# Altium schematic sheet P770010E05-Xx-SH-2_AIS.SchDoc(P770010E05-Xx-SH-2_AIS)
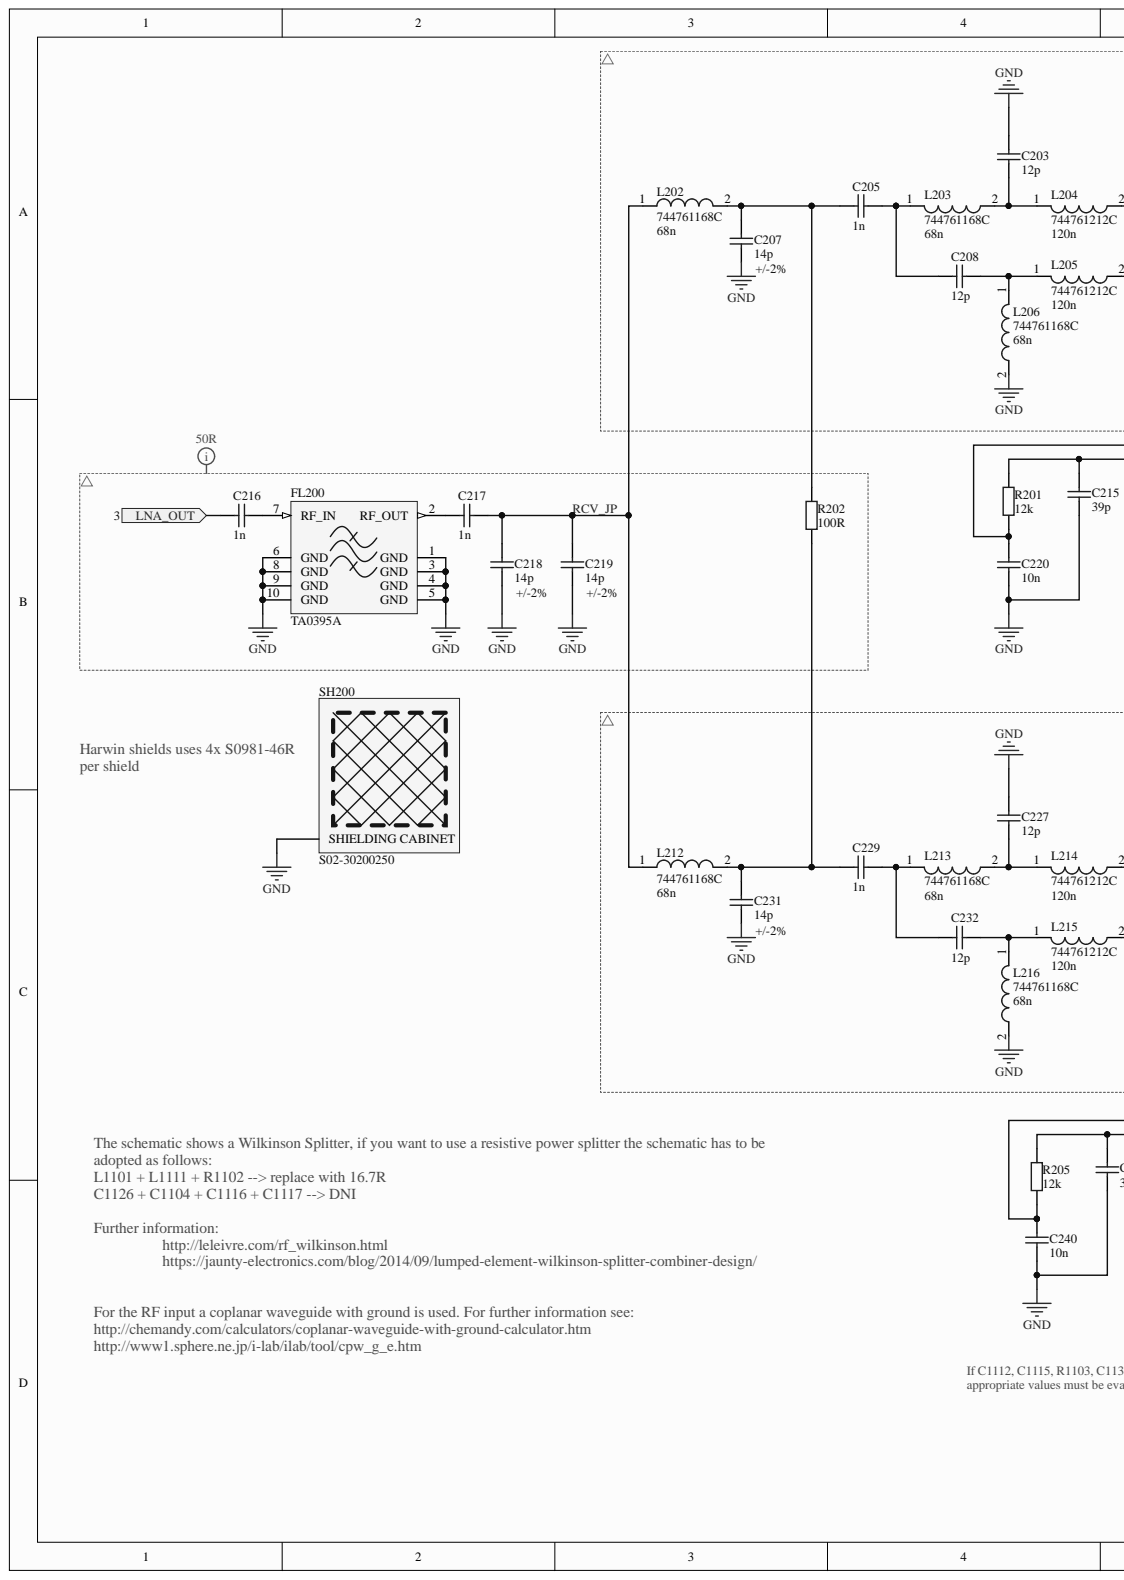
[diagram p0: P770010E05-Xx-SH-2_AIS.SchDoc, left]
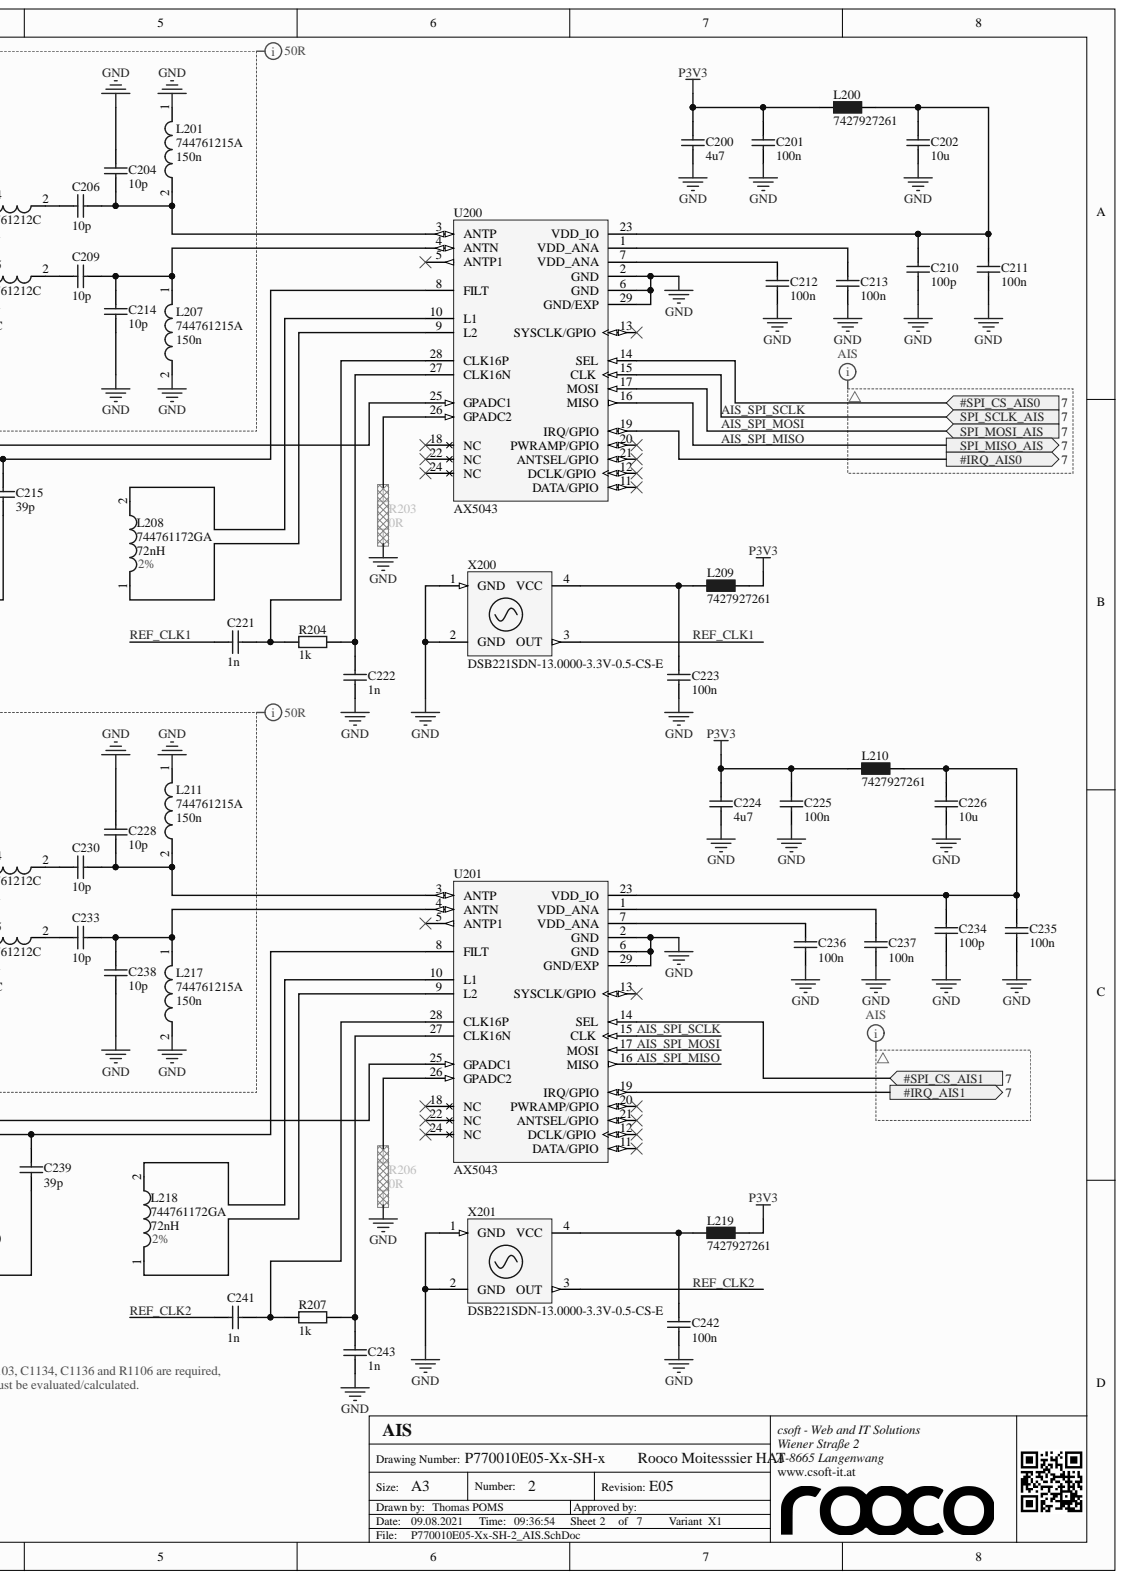
[diagram p1: P770010E05-Xx-SH-2_AIS.SchDoc, right]

## Parts
C200  4u7  [C0402]
C201  100n  [C0402]
C202  10u  [C0603]
C203  12p  [C0402]
C204  10p  [C0402]
C205  1n  [C0402]
C206  10p  [C0402]
C207  14p  ToleranceValue: +/-2%  [C0402]
C208  12p  [C0402]
C209  10p  [C0402]
C210  100p  [C0402]
C211  100n  [C0402]
C212  100n  [C0402]
C213  100n  [C0402]
C214  10p  [C0402]
C215  39p  [C0402]
C216  1n  [C0402]
C217  1n  [C0402]
C218  14p  ToleranceValue: +/-2%  [C0402]
C219  14p  ToleranceValue: +/-2%  [C0402]
C220  10n  [C0402]
C221  1n  [C0402]
C222  1n  [C0402]
C223  100n  [C0402]
C224  4u7  [C0402]
C225  100n  [C0402]
C226  10u  [C0603]
C227  12p  [C0402]
C228  10p  [C0402]
C229  1n  [C0402]
C230  10p  [C0402]
C231  14p  ToleranceValue: +/-2%  [C0402]
C232  12p  [C0402]
C233  10p  [C0402]
C234  100p  [C0402]
C235  100n  [C0402]
C236  100n  [C0402]
C237  100n  [C0402]
C238  10p  [C0402]
C239  39p  [C0402]
C240  10n  [C0402]
C241  1n  [C0402]
C242  100n  [C0402]
C243  1n  [C0402]
FL200  TA0395A  [TA0395A_with_bypass_but_no_resistor]
L200  7427927261  [7427927261]
L201  744761215A  value 150n  [744761215A_0603]
L202  744761168C  value 68n  [744761168C_0603]
L203  744761168C  value 68n  [744761168C_0603]
L204  744761212C  value 120n  [744761212C_0603]
L205  744761212C  value 120n  [744761212C_0603]
L206  744761168C  value 68n  [744761168C_0603]
L207  744761215A  value 150n  [744761215A_0603]
L208  744761172GA  value 72nH  [744761172A_0603]
L209  7427927261  [7427927261]
L210  7427927261  [7427927261]
L211  744761215A  value 150n  [744761215A_0603]
L212  744761168C  value 68n  [744761168C_0603]
L213  744761168C  value 68n  [744761168C_0603]
L214  744761212C  value 120n  [744761212C_0603]
L215  744761212C  value 120n  [744761212C_0603]
L216  744761168C  value 68n  [744761168C_0603]
L217  744761215A  value 150n  [744761215A_0603]
L218  744761172GA  value 72nH  [744761172A_0603]
L219  7427927261  [7427927261]
R201  12k  [R0402]
R202  100R  [R0402]
R203  0R  [R0402]
R204  1k  [R0402]
R205  12k  [R0402]
R206  0R  [R0402]
R207  1k  [R0402]
SH200  S02-30200250  [S02-30200250_Wuerth_36103305_fewer_pads_V2]
U200  AX5043  [AX5043_QFN-28]
U201  AX5043  [AX5043_QFN-28]
X200  DSB221SDN-13.0000-3.3V-0.5-CS-E  [DSB221SDN-x]
X201  DSB221SDN-13.0000-3.3V-0.5-CS-E  [DSB221SDN-x]

## Ports
#IRQ_AIS0, #IRQ_AIS1, #SPI_CS_AIS0, #SPI_CS_AIS1, LNA_OUT, SPI_MISO_AIS, SPI_MOSI_AIS, SPI_SCLK_AIS

## Nets
GND: C200.1 C201.1 C202.1 C203.1 C204.1 C207.1 C210.1 C211.1 C212.1 C213.1 C214.2 C215.1 C218.1 C219.1 C220.1 C222.1 C223.1 C224.1 C225.1 C226.1 C227.1 C228.1 C231.1 C234.1 C235.1 C236.1 C237.1 C238.2 C239.1 C240.1 C242.1 C243.1 FL200.1(GND) FL200.3(GND) FL200.4(GND) FL200.5(GND) FL200.6(GND) FL200.8(GND) FL200.9(GND) FL200.10(GND) L201.1 L206.2 L207.2 L211.1 L216.2 L217.2 R203.1 R206.1 SH200.1 U200.2(GND) U200.6(GND) U200.29(GND/EXP) U201.2(GND) U201.6(GND) U201.29(GND/EXP) X200.1(GND) X200.2(GND) X201.1(GND) X201.2(GND)
P3V3: C200.2 C201.2 C224.2 C225.2 L200.1 L209.2 L210.1 L219.2
#IRQ_AIS0: U200.19(IRQ/GPIO) ; port #IRQ_AIS0
#IRQ_AIS1: U201.19(IRQ/GPIO) ; port #IRQ_AIS1
#SPI_CS_AIS0: U200.14(SEL) ; port #SPI_CS_AIS0
#SPI_CS_AIS1: U201.14(SEL) ; port #SPI_CS_AIS1
AIS_SPI_MISO: U200.16(MISO) U201.16(MISO) ; port SPI_MISO_AIS
AIS_SPI_MOSI: U200.17(MOSI) U201.17(MOSI) ; port SPI_MOSI_AIS
AIS_SPI_SCLK: U200.15(CLK) U201.15(CLK) ; port SPI_SCLK_AIS
LNA_OUT: C216.1 ; port LNA_OUT
RCV_JP: C217.2 C218.2 C219.2 L202.1 L212.1
REF_CLK1: C221.1 X200.3(OUT)
REF_CLK2: C241.1 X201.3(OUT)
NetC202_2: C202.2 C210.2 C211.2 L200.2 U200.23(VDD_IO)
NetC203_2: C203.2 L203.2 L204.1
NetC204_2: C204.2 C206.2 L201.2 U200.3(ANTP)
NetC205_1: C205.1 C207.2 L202.2 R202.2
NetC205_2: C205.2 C208.1 L203.1
NetC206_1: C206.1 L204.2
NetC208_2: C208.2 L205.1 L206.1
NetC209_1: C209.1 L205.2
NetC209_2: C209.2 C214.1 L207.1 U200.4(ANTN)
NetC212_2: C212.2 U200.7(VDD_ANA)
NetC213_2: C213.2 U200.1(VDD_ANA)
NetC215_2: C215.2 R201.2 U200.8(FILT)
NetC216_2: C216.2 FL200.7(RF_IN)
NetC217_1: C217.1 FL200.2(RF_OUT)
NetC220_2: C220.2 R201.1 U200.25(GPADC1)
NetC221_2: C221.2 R204.2 U200.28(CLK16P)
NetC222_2: C222.2 R204.1 U200.27(CLK16N)
NetC223_2: C223.2 L209.1 X200.4(VCC)
NetC226_2: C226.2 C234.2 C235.2 L210.2 U201.23(VDD_IO)
NetC227_2: C227.2 L213.2 L214.1
NetC228_2: C228.2 C230.2 L211.2 U201.3(ANTP)
NetC229_1: C229.1 C231.2 L212.2 R202.1
NetC229_2: C229.2 C232.1 L213.1
NetC230_1: C230.1 L214.2
NetC232_2: C232.2 L215.1 L216.1
NetC233_1: C233.1 L215.2
NetC233_2: C233.2 C238.1 L217.1 U201.4(ANTN)
NetC236_2: C236.2 U201.7(VDD_ANA)
NetC237_2: C237.2 U201.1(VDD_ANA)
NetC239_2: C239.2 R205.2 U201.8(FILT)
NetC240_2: C240.2 R205.1 U201.25(GPADC1)
NetC241_2: C241.2 R207.2 U201.28(CLK16P)
NetC242_2: C242.2 L219.1 X201.4(VCC)
NetC243_2: C243.2 R207.1 U201.27(CLK16N)
NetL208_1: L208.1 U200.9(L2)
NetL208_2: L208.2 U200.10(L1)
NetL218_1: L218.1 U201.9(L2)
NetL218_2: L218.2 U201.10(L1)
NetR203_2: R203.2 U200.26(GPADC2)
NetR206_2: R206.2 U201.26(GPADC2)
N00068:
N00069:
N00070:
N00071:
N00072:

Not connected: U200 5(ANTP1) 11(DATA/GPIO) 12(DCLK/GPIO) 13(SYSCLK/GPIO) 18(NC) 20(PWRAMP/GPIO) 21(ANTSEL/GPIO) 22(NC) 24(NC); U201 5(ANTP1) 11(DATA/GPIO) 12(DCLK/GPIO) 13(SYSCLK/GPIO) 18(NC) 20(PWRAMP/GPIO) 21(ANTSEL/GPIO) 22(NC) 24(NC)

## Library data of the parts
C200 C224 = C1005X5R0J475K: ceramic chip capacitor, 4.7uF, 6.3V, X5R, 0402; Manufacturer: TDK; ID: 10; Obsolete: False; Published by: FT-TEC; RevisionHistory: [1.0]; ToleranceValue: +/-15%; Component Kind: Standard; ComponentLink1Description: Datasheet; ComponentLink1URL: http://www.tdk.com/pdf/TDKMLCCCapRange.pdf; ComponentType: Standard; Database Table Name: Capacitor; Dielectric: X5R; Library Name: CompanyDatabase.DbLib; Package: 0402; Part Number: C1005X5R0J475K; Pin Count: 2; Published: 24.02.2012; Revision: 1.0; TemperatureMax: 85°C; TemperatureMin: -55°C; Value: 4u7; VoltageTypical: 6.3V; Checked by: Thomas POMS; Drawn by: Thomas POMS
C201 C211-C213 C223 C225 C235-C237 C242 = C1005X7R1H104K050BB: ceramic chip capacitor, 100n, 50V, X7R, 0402; Manufacturer: TDK; ID: 1; Obsolete: False; Published by: FT-TEC; RevisionHistory: [1.0]; ToleranceValue: +/-10%; Component Kind: Standard; ComponentLink1Description: Datasheet; ComponentLink1URL: http://www.tdk.com/pdf/TDKMLCCCapRange.pdf; ComponentType: Standard; Database Table Name: Capacitor; Dielectric: X7R; Library Name: CompanyDatabase.DbLib; Package: 0402; Part Number: C1005X7R1H104K050BB; Pin Count: 2; Published: 16.02.2012; Revision: 1.0; TemperatureMax: 125°C; TemperatureMin: -55°C; Value: 100n; VoltageTypical: 50V; Checked by: Thomas POMS; Drawn by: Thomas POMS
C202 C226 = GRM188R61C106KAALJ: ceramic chip capacitor, 10uF, 16V, X5R, 0603; Manufacturer: Murata; ID: 68; Obsolete: False; Published by: FT-TEC; RevisionHistory: [1.0] Creation; ToleranceValue: +/-10%; Component Kind: Standard; ComponentLink1Description: Datasheet; ComponentLink1URL: http://search.murata.co.jp/Ceramy/image/img/A01X/partnumbering_e_01.pdf; ComponentType: Standard; Database Table Name: Capacitor; Dielectric: X5R; Library Name: CompanyDatabase.DbLib; Package: 0603; Part Number: GRM188R61C106KAALJ; Pin Count: 2; Published: 03.09.2014; Revision: 1.0; TemperatureMax: 85°C; TemperatureMin: -55°C; Value: 10u; VoltageTypical: 16V; Checked by: Thomas POMS; Drawn by: Thomas POMS
C203 C208 C227 C232 = CC0402JRNPO9BN120: ceramic chip capacitor, 12p, 50V, C0G, 0402ceramic chip c; Manufacturer: Yageo; ID: 4; Obsolete: False; Published by: FT-TEC; RevisionHistory: [1.0]; ToleranceValue: +/-5%; Component Kind: Standard; ComponentLink1Description: Datasheet; ComponentLink1URL: http://www.yageo.com/documents/recent/UPY-GP_NP0_16V-to-50V_8.pdf; ComponentType: Standard; Database Table Name: Capacitor; Dielectric: C0G; Library Name: CompanyDatabase.DbLib; Package: 0402; Part Number: CC0402JRNPO9BN120; Pin Count: 2; Published: 16.02.2012; Revision: 1.0; TemperatureMax: 125°C; TemperatureMin: -55°C; Value: 12p; VoltageTypical: 50V; Checked by: Thomas POMS; Drawn by: Thomas POMS
C204 C206 C209 C214 C228 C230 C233 C238 = C0402C100C5GACTU: ceramic chip capacitor, 10pF, 50V, NP0, 0402; Manufacturer: Kemet; ID: 55; Obsolete: False; Published by: FT-TEC; RevisionHistory: [1.0] Creation; ToleranceValue: +/-0.25%; Component Kind: Standard; ComponentLink1Description: Datasheet; ComponentLink1URL: http://www.kemet.com/kemet/web/homepage/kechome.nsf/vapubfiles/KEM_C1003_C0G_SMD.pdf/$file/KEM_C1003_C0G_SMD.pdf; ComponentType: Standard; Database Table Name: Capacitor; Dielectric: NP0; Library Name: CompanyDatabase.DbLib; Package: 0402; Part Number: C0402C100C5GACTU; Pin Count: 2; Published: 17.03.2014; Revision: 1.0; TemperatureMax: 125°C; TemperatureMin: -55°C; Value: 10p; VoltageTypical: 50V; Checked by: Thomas POMS; Drawn by: Thomas POMS
C205 C216 C217 C221 C222 C229 C241 C243 = C1005C0G1H102G050BA: ceramic chip capacitor, 1nF, 50V, NP0, 0402; Manufacturer: TDK; ID: 25; Obsolete: False; Published by: FT-TEC; RevisionHistory: [1.0]; ToleranceValue: +/-2%; Component Kind: Standard; ComponentLink1Description: Datasheet; ComponentLink1URL: http://www.tdk.com/pdf/tight_tol_B11.pdf; ComponentType: Standard; Database Table Name: Capacitor; Dielectric: NP0; Library Name: CompanyDatabase.DbLib; Package: 0402; Part Number: C1005C0G1H102G050BA; Pin Count: 2; Published: 26.02.2013; Revision: 1.0; TemperatureMax: 125°C; TemperatureMin: -55°C; Value: 1n; VoltageTypical: 50V; Checked by: Thomas POMS; Drawn by: Thomas POMS
C207 C218 C219 C231 = 04023U140GAT2A: ceramic chip capacitor, 14pF, 25V, NP0, 0402; Manufacturer: AVX; ID: 69; Obsolete: False; Published by: FT-TEC; RevisionHistory: [1.0] Creation; Component Kind: Standard; ComponentLink1Description: Datasheet; ComponentLink1URL: http://www.avx.com/docs/Catalogs/useries.pdf; ComponentType: Standard; Database Table Name: Capacitor; Dielectric: NP0; Library Name: CompanyDatabase.DbLib; Package: 0402; Part Number: 04023U140GAT2A; Pin Count: 2; Published: 28.10.2014; Revision: 1.0; TemperatureMax: 125°C; TemperatureMin: -55°C; Value: 14p; VoltageTypical: 25V; Checked by: Thomas POMS; Drawn by: Thomas POMS
C210 C234 = UMK105CG101JV: ceramic chip capacitor, 100pF, 50V, C0G, 0402; Manufacturer: Taiyo Yuden; ID: 17; Obsolete: False; Published by: FT-TEC; RevisionHistory: [1.0]; ToleranceValue: +/-5%; Component Kind: Standard; ComponentLink1Description: Datasheet; ComponentLink1URL: http://media.digikey.com/pdf/Data%20Sheets/Taiyo%20Yuden%20PDFs%20URL%20links/Standard%20Multilayer%20Ceramic%20Caps.pdf; ComponentType: Standard; Database Table Name: Capacitor; Dielectric: C0G; Library Name: CompanyDatabase.DbLib; Package: 0402; Part Number: UMK105CG101JV; Pin Count: 2; Published: 27.02.2012; Revision: 1.0; TemperatureMax: 125°C; TemperatureMin: -55°C; Value: 100p; VoltageTypical: 50V; Checked by: Thomas POMS; Drawn by: Thomas POMS
C215 C239 = C0402C390J5GACTU: ceramic chip capacitor, 39pF, 50V, NP0, 0402; Manufacturer: Kemet; ID: 72; Obsolete: False; Published by: FT-TEC; RevisionHistory: [1.0] Creation; ToleranceValue: +/-5%; Component Kind: Standard; ComponentLink1Description: Datasheet; ComponentLink1URL: http://www.kemet.com/Lists/ProductCatalog/Attachments/46/KEM_C1003_C0G_SMD.pdf; ComponentType: Standard; Database Table Name: Capacitor; Dielectric: NP0; Library Name: CompanyDatabase.DbLib; Package: 0402; Part Number: C0402C390J5GACTU; Pin Count: 2; Published: 14.02.2015; Revision: 1.0; TemperatureMax: 125°C; TemperatureMin: -55°C; Value: 39p; VoltageTypical: 50V; Checked by: Thomas POMS; Drawn by: Thomas POMS
C220 C240 = GCM155R71H103KA55D: ceramic chip capacitor, 10nF, 50V, X7R, 0402; Manufacturer: Murata; ID: 13; Obsolete: False; Published by: FT-TEC; RevisionHistory: [1.0]; ToleranceValue: +/-10%; Component Kind: Standard; ComponentLink1Description: Datasheet; ComponentLink1URL: http://search.murata.co.jp/Ceramy/image/img/PDF/ENG/GCM155R71H103KA55.pdf; ComponentType: Standard; Database Table Name: Capacitor; Dielectric: X7R; Library Name: CompanyDatabase.DbLib; Package: 0402; Part Number: GCM155R71H103KA55D; Pin Count: 2; Published: 26.02.2012; Revision: 1.0; TemperatureMax: 125°C; TemperatureMin: -55°C; Value: 10n; VoltageTypical: 50V; Checked by: Thomas POMS; Drawn by: Thomas POMS
FL200 = TA0395A: SAW filter 159.1625 MHz, max. 20 dBm continuous power input level; Manufacturer: Golledge; Database Table Name: RF_Filter; ID: 1; Obsolete: False; Published by: FT-TEC; RevisionHistory: [1.0] Creation; Component Kind: Standard; ComponentType: Standard; Library Name: CompanyDatabase.DbLib; Package: 7.0x5.0mm; Part Number: TA0395A; Pin Count: 10; Published: 08.07.2013; Revision: 1.0; TemperatureMax: 70°C; TemperatureMin: -30°C; Value: TA0395A; Checked by: Thomas POMS; Drawn by: Thomas POMS
L200 L209 L210 L219 = 7427927261: EMI suppression ferrite bead, 100mA, 0.6R, Z=600R@100MHz; Manufacturer: Würth; Database Table Name: Inductor; ID: 12; Obsolete: False; Published by: FT-TEC; RevisionHistory: [1.0] Creation; Component Kind: Standard; ComponentLink1Description: Datasheet; ComponentLink1URL: http://katalog.we-online.de/kataloge/eisos/media/pdf/7427927261.pdf; ComponentType: Standard; CurrentRating: 100mA; Impedance: 600R@100MHz; Library Name: CompanyDatabase.DbLib; Package: 0402; Part Number: 7427927261; Pin Count: 2; Published: 02.04.2012; ResistanceDCmax: 0.6R; Revision: 1.0; TemperatureMax: 125°C; TemperatureMin: -55°C; Checked by: Thomas POMS; Drawn by: Thomas POMS
L201 L207 L211 L217 = 744761215A: ceramic chip inductor, 0603, 150 nH,  280 mA, 0.92 RDC; Manufacturer: Würth; CurrentRating: 280mA; ID: 18; Obsolete: False; Published by: FT-TEC; ResistanceDCmax: 0.93R; RevisionHistory: [1.0] Creation; Component Kind: Standard; ComponentLink1Description: Datasheet; ComponentLink1URL: http://katalog.we-online.de/pbs/datasheet/744761215A.pdf; ComponentType: Standard; Database Table Name: Inductor; Library Name: CompanyDatabase.DbLib; Package: 0603; Part Number: 744761215A; Pin Count: 2; Published: 08.07.2013; Revision: 1.0; TemperatureMax: 125°C; TemperatureMin: -40°C; Checked by: Thomas POMS; Drawn by: Thomas POMS
L202 L203 L206 L212 L213 L216 = 744761168C: ceramic chip inductor, 0603, 68nH,  600mA, 0.35RDC; Manufacturer: Würth; ID: 34; Obsolete: False; Published by: FT-TEC; ResistanceDCmax: 0.35R; RevisionHistory: [1.0] Creation; Component Kind: Standard; ComponentLink1Description: Datasheet; ComponentLink1URL: http://katalog.we-online.de/pbs/datasheet/744761168C.pdf; ComponentType: Standard; CurrentRating: 600mA; Database Table Name: Inductor; Library Name: CompanyDatabase.DbLib; Package: 0603; Part Number: 744761168C; Pin Count: 2; Published: 28.10.2014; Revision: 1.0; TemperatureMax: 125°C; TemperatureMin: -40°C; Checked by: Thomas POMS; Drawn by: Thomas POMS
L204 L205 L214 L215 = 744761212C: wire wound ceramic inductor, 0603, 120nH, 300mA, 0.65RDC, Q=30@150MHz; Manufacturer: Würth; ID: 36; Obsolete: False; Published by: FT-TEC; ResistanceDCmax: 0.65R; RevisionHistory: [1.0] Creation; Component Kind: Standard; ComponentLink1Description: Datasheet; ComponentLink1URL: http://katalog.we-online.de/pbs/datasheet/744761212C.pdf; ComponentType: Standard; CurrentRating: 300mA; Database Table Name: Inductor; Library Name: CompanyDatabase.DbLib; Package: 0603; Part Number: 744761212C; Pin Count: 2; Published: 14.02.2015; Revision: 1.0; TemperatureMax: 125°C; TemperatureMin: -40°C; Checked by: Thomas POMS; Drawn by: Thomas POMS
L208 L218 = 744761172GA: wire wound ceramic inductor, 0603, 72nH, 2%, 400mA, 0.49RDC, Q=35@150MHz; Manufacturer: Würth; ID: 53; Obsolete: False; Published by: poms-engineering; ResistanceDCmax: 0.49R; RevisionHistory: [1.0] Creation; Component Kind: Standard; ComponentLink1Description: Datasheet; ComponentLink1URL: http://katalog.we-online.de/pbs/datasheet/744761172A.pdf; ComponentType: Standard; CurrentRating: 400mA; Database Table Name: Inductor; Library Name: CompanyDatabase.DbLib; Package: 0603; Part Number: 744761172GA; Pin Count: 2; Published: 03.04.2018; Revision: 1.0; TemperatureMax: 125°C; TemperatureMin: -40°C; Checked by: Thomas POMS; Drawn by: Thomas POMS
R201 R205 = CRCW040212K0FKED: thick film resistor, 12k, 1%, 100ppm, 0402, 63mW; Manufacturer: Vishay; ID: 103; Obsolete: False; Published by: FT-TEC; RevisionHistory: [1.0] Creation; VoltageRating: 50V; Component Kind: Standard; ComponentLink1Description: Datasheet; ComponentLink1URL: http://www.vishay.com/docs/20035/dcrcwe3.pdf; ComponentType: Standard; Database Table Name: Resistor; Library Name: CompanyDatabase.DbLib; Package: 0402; Part Number: CRCW040212K0FKED; Pin Count: 2; PowerRating: 63mW; Published: 04.04.2017; Revision: 1.0; TemperatureCoefficient: 100ppm; TemperatureMax: 155°C; TemperatureMin: -55°C; ToleranceValue: 1%; Value: 12k; Checked by: Thomas POMS; Drawn by: Thomas POMS
R202 = CRCW0402100RFKED: thick film resistor, 100R, 1%, 100ppm,  0402; Manufacturer: Vishay; ID: 18; Obsolete: False; PowerRating: 63mW; Published by: FT-TEC; RevisionHistory: [1.0] Creation; VoltageRating: 50V; Component Kind: Standard; ComponentLink1Description: Datasheet; ComponentLink1URL: http://www.vishay.com/docs/20035/dcrcwe3.pdf; ComponentType: Standard; Database Table Name: Resistor; Library Name: CompanyDatabase.DbLib; Package: 0402; Part Number: CRCW0402100RFKED; Pin Count: 2; Published: 27.02.2012; Revision: 1.0; TemperatureCoefficient: 100ppm; TemperatureMax: 155°C; TemperatureMin: -55°C; ToleranceValue: 1%; Value: 100R; Checked by: Thomas POMS; Drawn by: Thomas Poms
R203 R206 = CRCW04020000Z0ED: thick film resistor, 0R, 1%, 100ppm, 0402; Manufacturer: Vishay; ID: 2; Obsolete: False; PowerRating: 63mW; Published by: FT-TEC; RevisionHistory: [1.0] Creation; VoltageRating: 50V; Component Kind: Standard; ComponentType: Standard; Database Table Name: Resistor; Library Name: CompanyDatabase.DbLib; Package: 0402; Part Number: CRCW04020000Z0ED; Pin Count: 2; Published: 16.02.2012; Revision: 1.0; TemperatureCoefficient: 100ppm; TemperatureMax: 155°C; TemperatureMin: -55°C; ToleranceValue: 1%; Value: 0R; Checked by: Thomas POMS; Drawn by: Thomas Poms
R204 R207 = CRCW04021K00FKED: thick film resistor, 1k, 1%, 100ppm, 0402; Manufacturer: Vishay; ID: 4; Obsolete: False; PowerRating: 63mW; Published by: FT-TEC; RevisionHistory: [1.0] Creation; VoltageRating: 50V; Component Kind: Standard; ComponentLink1Description: Datasheet; ComponentLink1URL: http://www.vishay.com/docs/20035/dcrcwe3.pdf; ComponentType: Standard; Database Table Name: Resistor; Library Name: CompanyDatabase.DbLib; Package: 0402; Part Number: CRCW04021K00FKED; Pin Count: 2; Published: 16.02.2012; Revision: 1.0; TemperatureCoefficient: 100ppm; TemperatureMax: 155°C; TemperatureMin: -55°C; ToleranceValue: 1%; Value: 1k; Checked by: Thomas POMS; Drawn by: Thomas Poms
SH200 = S02-30200250: shielding cabinet, 30x20x3, 4x S1721-46R or  4x S0981-46R clips required (depending on footprint)!!!; Manufacturer: Harwin; Database Table Name: Schielding_Cabinet; ID: 10; Obsolete: False; Published by: FT-TEC; RevisionHistory: [1.0] Creation; Component Kind: Standard; ComponentLink1Description: Datasheet; ComponentLink1URL: https://cdn.harwin.com/pdfs/S02-XXXXXXX0.pdf; ComponentType: Standard; Library Name: CompanyDatabase.DbLib; Package: 30x20x3mm; Part Number: S02-30200250; Published: 19.10.2017; Revision: 1.0; Value: S02-30200250; Checked by: Thomas POMS; Drawn by: Thomas POMS
U200 U201 = AX5043: advanced high performance ASK and FSK narrow-band transceiver for 27-1050MHz range; Manufacturer: AXSEM; Database Table Name: RF_Transceiver; ID: 2; Obsolete: False; Published by: FT-TEC; RevisionHistory: [1.0] Creation; Component Kind: Standard; ComponentLink1Description: Datasheet; ComponentLink1URL: http://www.axsem.com/www/component/jdownloads/finish/6/16; ComponentType: Standard; Library Name: CompanyDatabase.DbLib; Package: QFN-28; Part Number: AX5043; Pin Count: 28; Published: 16.07.2015; Revision: 1.0; TemperatureMax: 85°C; TemperatureMin: -40°C; Value: AX5043; Checked by: Thomas POMS; Drawn by: Thomas POMS
X200 X201 = DSB221SDN-13.0000-3.3V-0.5-CS-E: TCXO, 13MHz, -40°C - 85°C, clipped sinde, +/- 0.5ppm frequency stability; Manufacturer: DAISHINKU CORP.; Ageing: +/-1ppm max first year; Database Table Name: Oscillator; ID: 6; Obsolete: False; OutputWaveform: clipped sine, 0.8Vpp; Published by: FT-TEC; RevisionHistory: [1.0] Creation; VoltageMax: 3.3V; VoltageMin: 3.135V; VoltageTypical: 3.465V; Component Kind: Standard; ComponentType: Standard; Frequency: 13MHz; FrequencyStability: +/-0.5ppm; Library Name: CompanyDatabase.DbLib; Package: 2.5x2.0mm; Part Number: DSB221SDN-13.0000-3.3V-0.5-CS-E; Pin Count: 4; Published: 24.12.2015; Revision: 1.0; TemperatureMax: 85°C; TemperatureMin: -40°C; Value: DSB221SDN-13.0000-3.3V-0.5-CS-E; Checked by: Thomas POMS; Drawn by: Thomas POMS
